# Altium schematic sheet RESISTORS.SchDoc
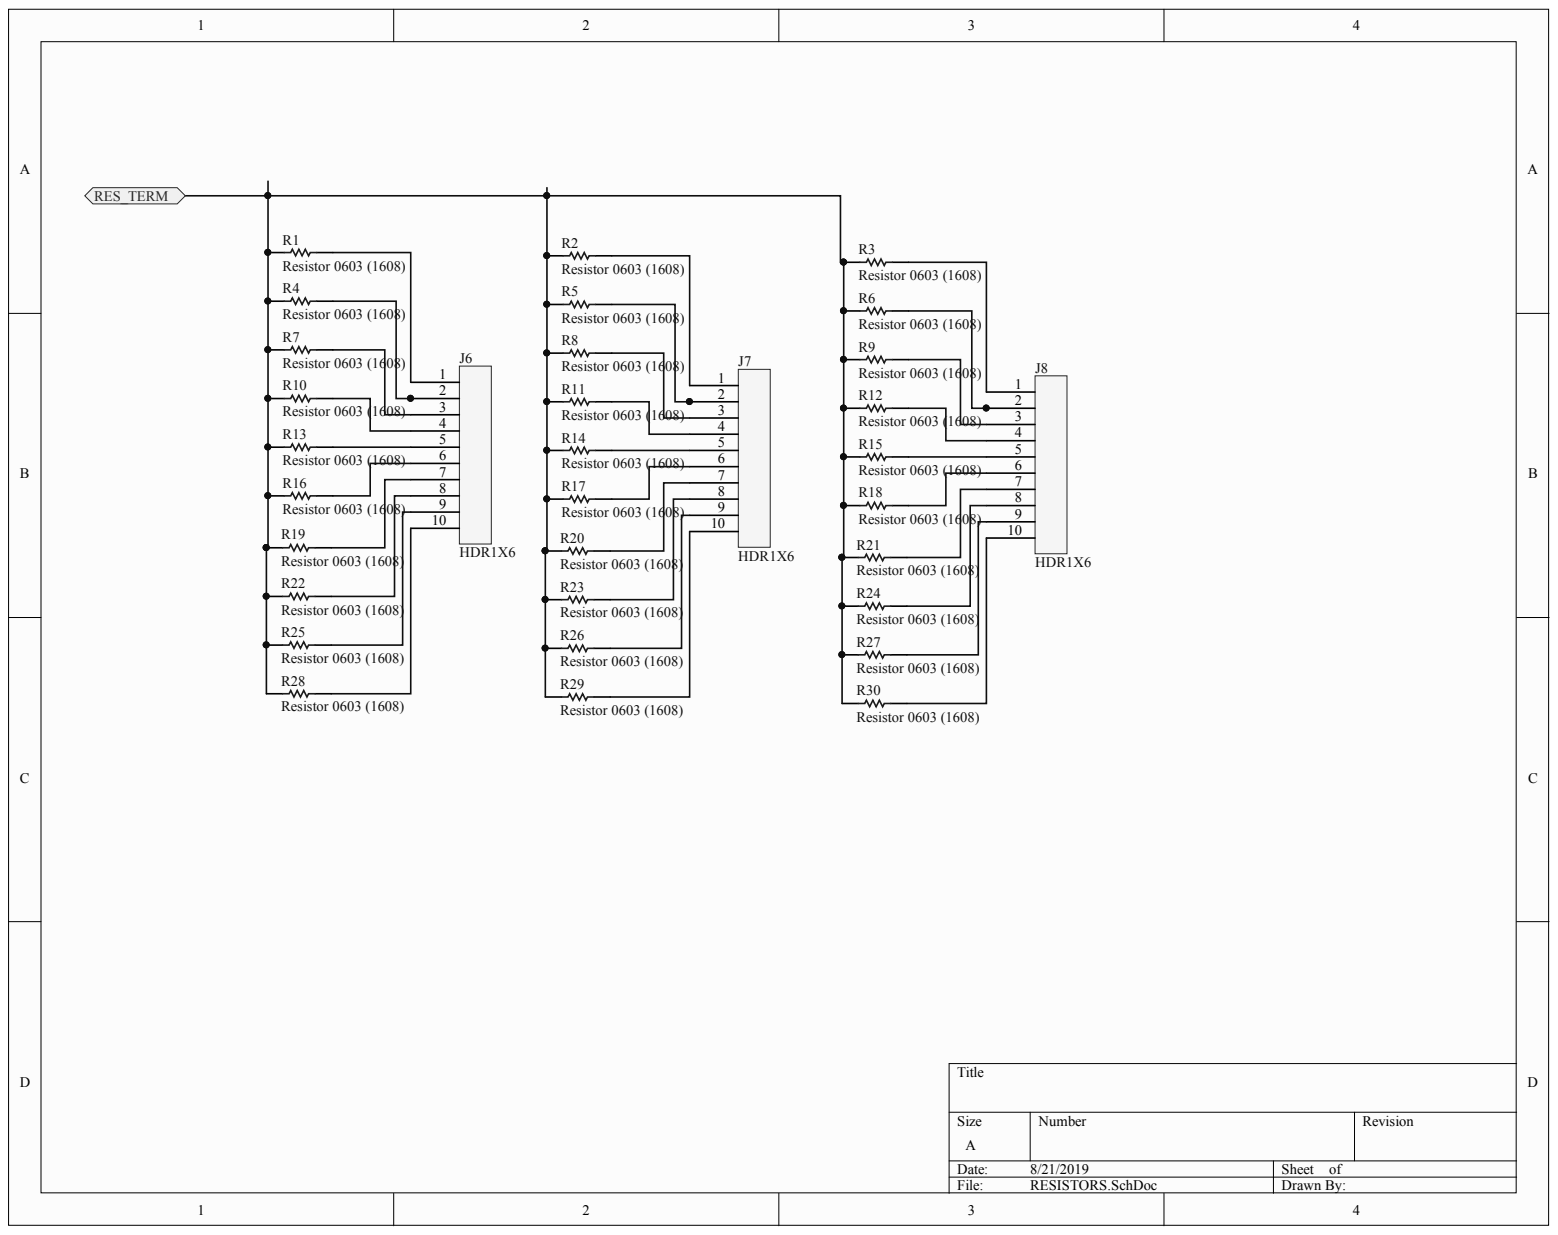
[diagram p0: RESISTORS.SchDoc]

## Parts
J6  HDR1X6  [HDR1X10]
J7  HDR1X6  [HDR1X10]
J8  HDR1X6  [HDR1X10]
R1  Resistor 0603 (1608)  [PCB-8xtmhdk62wywm3ayihyv-1]
R2  Resistor 0603 (1608)  [PCB-8xtmhdk62wywm3ayihyv-1]
R3  Resistor 0603 (1608)  [PCB-8xtmhdk62wywm3ayihyv-1]
R4  Resistor 0603 (1608)  [PCB-8xtmhdk62wywm3ayihyv-1]
R5  Resistor 0603 (1608)  [PCB-8xtmhdk62wywm3ayihyv-1]
R6  Resistor 0603 (1608)  [PCB-8xtmhdk62wywm3ayihyv-1]
R7  Resistor 0603 (1608)  [PCB-8xtmhdk62wywm3ayihyv-1]
R8  Resistor 0603 (1608)  [PCB-8xtmhdk62wywm3ayihyv-1]
R9  Resistor 0603 (1608)  [PCB-8xtmhdk62wywm3ayihyv-1]
R10  Resistor 0603 (1608)  [PCB-8xtmhdk62wywm3ayihyv-1]
R11  Resistor 0603 (1608)  [PCB-8xtmhdk62wywm3ayihyv-1]
R12  Resistor 0603 (1608)  [PCB-8xtmhdk62wywm3ayihyv-1]
R13  Resistor 0603 (1608)  [PCB-8xtmhdk62wywm3ayihyv-1]
R14  Resistor 0603 (1608)  [PCB-8xtmhdk62wywm3ayihyv-1]
R15  Resistor 0603 (1608)  [PCB-8xtmhdk62wywm3ayihyv-1]
R16  Resistor 0603 (1608)  [PCB-8xtmhdk62wywm3ayihyv-1]
R17  Resistor 0603 (1608)  [PCB-8xtmhdk62wywm3ayihyv-1]
R18  Resistor 0603 (1608)  [PCB-8xtmhdk62wywm3ayihyv-1]
R19  Resistor 0603 (1608)  [PCB-8xtmhdk62wywm3ayihyv-1]
R20  Resistor 0603 (1608)  [PCB-8xtmhdk62wywm3ayihyv-1]
R21  Resistor 0603 (1608)  [PCB-8xtmhdk62wywm3ayihyv-1]
R22  Resistor 0603 (1608)  [PCB-8xtmhdk62wywm3ayihyv-1]
R23  Resistor 0603 (1608)  [PCB-8xtmhdk62wywm3ayihyv-1]
R24  Resistor 0603 (1608)  [PCB-8xtmhdk62wywm3ayihyv-1]
R25  Resistor 0603 (1608)  [PCB-8xtmhdk62wywm3ayihyv-1]
R26  Resistor 0603 (1608)  [PCB-8xtmhdk62wywm3ayihyv-1]
R27  Resistor 0603 (1608)  [PCB-8xtmhdk62wywm3ayihyv-1]
R28  Resistor 0603 (1608)  [PCB-8xtmhdk62wywm3ayihyv-1]
R29  Resistor 0603 (1608)  [PCB-8xtmhdk62wywm3ayihyv-1]
R30  Resistor 0603 (1608)  [PCB-8xtmhdk62wywm3ayihyv-1]

## Ports
RES_TERM

## Nets
RES_TERM: R1.1 R2.1 R3.1 R4.1 R5.1 R6.1 R7.1 R8.1 R9.1 R10.1 R11.1 R12.1 R13.1 R14.1 R15.1 R16.1 R17.1 R18.1 R19.1 R20.1 R21.1 R22.1 R23.1 R24.1 R25.1 R26.1 R27.1 R28.1 R29.1 R30.1 ; port RES_TERM
NetJ6_1: J6.1 R1.2
NetJ6_2: J6.2 R4.2
NetJ6_3: J6.3 R7.2
NetJ6_4: J6.4 R10.2
NetJ6_5: J6.5 R13.2
NetJ6_6: J6.6 R16.2
NetJ6_7: J6.7 R19.2
NetJ6_8: J6.8 R22.2
NetJ6_9: J6.9 R25.2
NetJ6_10: J6.10 R28.2
NetJ7_1: J7.1 R2.2
NetJ7_2: J7.2 R5.2
NetJ7_3: J7.3 R8.2
NetJ7_4: J7.4 R11.2
NetJ7_5: J7.5 R14.2
NetJ7_6: J7.6 R17.2
NetJ7_7: J7.7 R20.2
NetJ7_8: J7.8 R23.2
NetJ7_9: J7.9 R26.2
NetJ7_10: J7.10 R29.2
NetJ8_1: J8.1 R3.2
NetJ8_2: J8.2 R6.2
NetJ8_3: J8.3 R9.2
NetJ8_4: J8.4 R12.2
NetJ8_5: J8.5 R15.2
NetJ8_6: J8.6 R18.2
NetJ8_7: J8.7 R21.2
NetJ8_8: J8.8 R24.2
NetJ8_9: J8.9 R27.2
NetJ8_10: J8.10 R30.2

## Library data of the parts
J6-J8 = CMP-4d308488f3b592f7-3: 2.54mm (.100") Pitch KK® Header, Breakaway, Vertical, 10 Circuits, Tin (Sn) Plating, Mating Pin Length 6.09mm (.240"); Manufacturer Part Number: 0022284100; Gender: Male; Component Kind: Standard; Library Name: CommunityVault; Mounting Style: Solder; OctopartID: 4d308488f3b592f7; Octopart URL: https://octopart.com/0022284100-molex-11705315; RoHS: Compliant
R1-R30 = CMP-8d0d5kw4b9o869c0if8y-1: Generic Resistor pad 0603 Imperial and 1608 Metric; Resistance: ~Ohms; Case/Package: 0603 (1608); Component Kind: Standard; CustomComponentID: 8d0d5kw4b9o869c0if8y; Library Name: FavoritesLibrary
